# Altium schematic sheet Sheet1.SchDoc
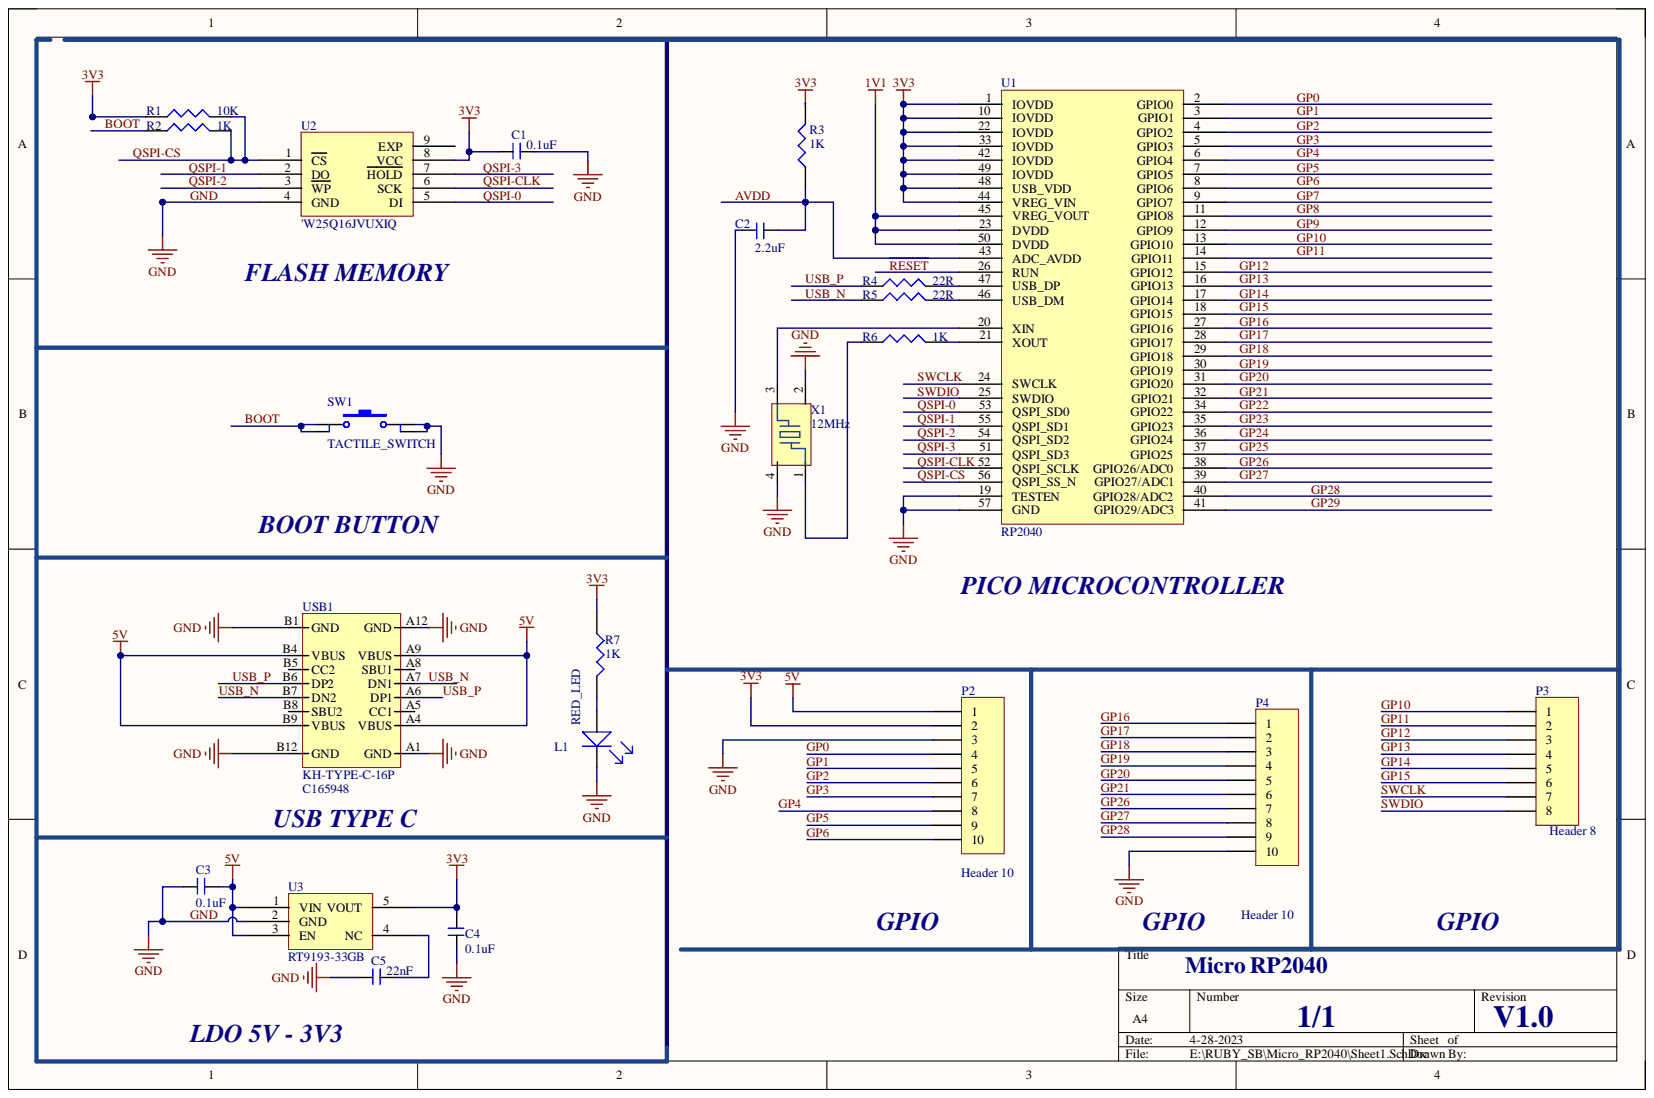
[diagram p0: Sheet1.SchDoc]

## Parts
C1  0.1uF  [C_0603]
C2  2.2uF  [C_0603]
C3  0.1uF  [C_0603]
C4  0.1uF  [C_0603]
C5  22nF  [C_0603]
L1  RED_LED  [LED_0603]
P2  Header 10  [edge 10P]
P3  Header 8  [EDGE _8P]
P4  Header 10  [edge 10P]
R1  10K  [R_0603]
R2  1K  [R_0603]
R3  1K  [R_0603]
R4  22R  [R_0603]
R5  22R  [R_0603]
R6  1K  [R_0603]
R7  1K  [R_0603]
SW1  TACTILE_SWITCH  [SKRPACE010]
U1  RP2040  [QFN56]
U2  'W25Q16JVUXIQ  [USON-8]
U3  RT9193-33GB  [SOT23-5]
USB1  KH-TYPE-C-16P  Supplier Part Number 1: C165948  [USB TYPE C]
X1  12MHz  [crystal]

## Nets
1V1: U1.23(DVDD) U1.45(VREG_VOUT) U1.50(DVDD)
3V3: C1.2 C4.1 P2.2 R1.1 R3.2 R7.1 U1.1(IOVDD) U1.10(IOVDD) U1.22(IOVDD) U1.33(IOVDD) U1.42(IOVDD) U1.44(VREG_VIN) U1.48(USB_VDD) U1.49(IOVDD) U2.8(VCC) U3.5(VOUT)
5V: C3.1 P2.1 U3.1(VIN) U3.3(EN) USB1.A4(VBUS) USB1.A9(VBUS) USB1.B4(VBUS) USB1.B9(VBUS)
AVDD: C2.2 R3.1 U1.43(ADC_AVDD)
BOOT: R2.1 SW1.1 SW1.2
GND: C1.1 C2.1 C3.2 C4.2 C5.2 L1.K P2.3 P4.10 SW1.3 SW1.4 U1.19(TESTEN) U1.57(GND) U2.4(GND) U3.2(GND) USB1.A1(GND) USB1.A12(GND) USB1.B1(GND) USB1.B12(GND) X1.2 X1.4
GP0: P2.4 U1.2(GPIO0)
GP1: P2.5 U1.3(GPIO1)
GP2: P2.6 U1.4(GPIO2)
GP3: P2.7 U1.5(GPIO3)
GP4: P2.8 U1.6(GPIO4)
GP5: P2.9 U1.7(GPIO5)
GP6: P2.10 U1.8(GPIO6)
GP7: U1.9(GPIO7)
GP8: U1.11(GPIO8)
GP9: U1.12(GPIO9)
GP10: P3.1 U1.13(GPIO10)
GP11: P3.2 U1.14(GPIO11)
GP12: P3.3 U1.15(GPIO12)
GP13: P3.4 U1.16(GPIO13)
GP14: P3.5 U1.17(GPIO14)
GP15: P3.6 U1.18(GPIO15)
GP16: P4.1 U1.27(GPIO16)
GP17: P4.2 U1.28(GPIO17)
GP18: P4.3 U1.29(GPIO18)
GP19: P4.4 U1.30(GPIO19)
GP20: P4.5 U1.31(GPIO20)
GP21: P4.6 U1.32(GPIO21)
GP22: U1.34(GPIO22)
GP23: U1.35(GPIO23)
GP24: U1.36(GPIO24)
GP25: U1.37(GPIO25)
GP26: P4.7 U1.38(GPIO26/ADC0)
GP27: P4.8 U1.39(GPIO27/ADC1)
GP28: P4.9 U1.40(GPIO28/ADC2)
GP29: U1.41(GPIO29/ADC3)
QSPI-0: U1.53(QSPI_SD0) U2.5(DI)
QSPI-1: U1.55(QSPI_SD1) U2.2(DO)
QSPI-2: U1.54(QSPI_SD2) U2.3(WP)
QSPI-3: U1.51(QSPI_SD3) U2.7(HOLD)
QSPI-CLK: U1.52(QSPI_SCLK) U2.6(SCK)
QSPI-CS: R1.2 R2.2 U1.56(QSPI_SS_N) U2.1(CS)
~{RESET}: U1.26(RUN)
SWCLK: P3.7 U1.24(SWCLK)
SWDIO: P3.8 U1.25(SWDIO)
USB_N: R5.1 USB1.A7(DN1) USB1.B7(DN2)
USB_P: R4.1 USB1.A6(DP1) USB1.B6(DP2)
NetC5_1: C5.1 U3.4(NC)
NetL1_A: L1.A R7.2
NetR4_2: R4.2 U1.47(USB_DP)
NetR5_2: R5.2 U1.46(USB_DM)
NetR6_1: R6.1 X1.1
NetR6_2: R6.2 U1.21(XOUT)
NetU1_20: U1.20(XIN) X1.3

Not connected: U2 9(EXP); USB1 A5(CC1) A8(SBU1) B5(CC2) B8(SBU2)

## Library data of the parts
C1-C5 = CAP_NONPOLAR: Component Kind: Standard; Library Name: dxp_pasl_lib.IntLib
L1 = LED: Component Kind: Standard; Library Name: dxp_pasl_lib.IntLib
P2 P4 = Header 10: Header, 10-Pin; Component Kind: Standard; LatestRevisionDate: 17-Jul-2002; LatestRevisionNote: Re-released for DXP Platform.; Library Name: Miscellaneous Connectors.IntLib; Signal Integrity: Connector; Publisher: Altium Limited
P3 = Header 8: Header, 8-Pin; Component Kind: Standard; LatestRevisionDate: 17-Jul-2002; LatestRevisionNote: Re-released for DXP Platform.; Library Name: Miscellaneous Connectors.IntLib; Signal Integrity: Connector; Publisher: Altium Limited
R1-R7 = RES: Component Kind: Standard; Library Name: dxp_pasl_lib.IntLib
U1 = RP2040: A MICROCONTROLLER BY RPI; Component Kind: Standard; Library Name: dxp_pasl_lib.IntLib
U2 = W25Q16FWUXIE: FLASH - NOR USON-8_2x3mm ROHS #C401672; Component Kind: Standard; Library Name: dxp_pasl_lib.IntLib
U3 = AP2112: 600mA CMOS LDO REGULATOR WITH ENABLE SOT-23-5 PACKAGE; Component Kind: Standard; Library Name: dxp_pasl_lib.IntLib
USB1 = USB TYPE-C-31-M-12: 250mA 1 16 Female Type-C SMD USB Connectors ROHS  #  C165948; Component Kind: Standard; ComponentLink1Description: New link; ComponentLink1URL: https://lcsc.com/product-detail/USB-Connectors_Korean-Hroparts-Elec-TYPE-C-31-M-12_C165948.html; Supplier 1: LCSC; Library Name: dxp_pasl_lib.IntLib
X1 = CRYSTAL_OSCILATOR: 12MHz ±10ppm 12pF 80Ω SMD-3225_4P Crystals RoHS #C70565; Component Kind: Standard; Library Name: dxp_pasl_lib.IntLib
SW1 = TACTILE_SWITCH: Component Kind: Standard; Library Name: dxp_pasl_lib.IntLib
